# Altium schematic sheet mega module.SchDoc("mega module")
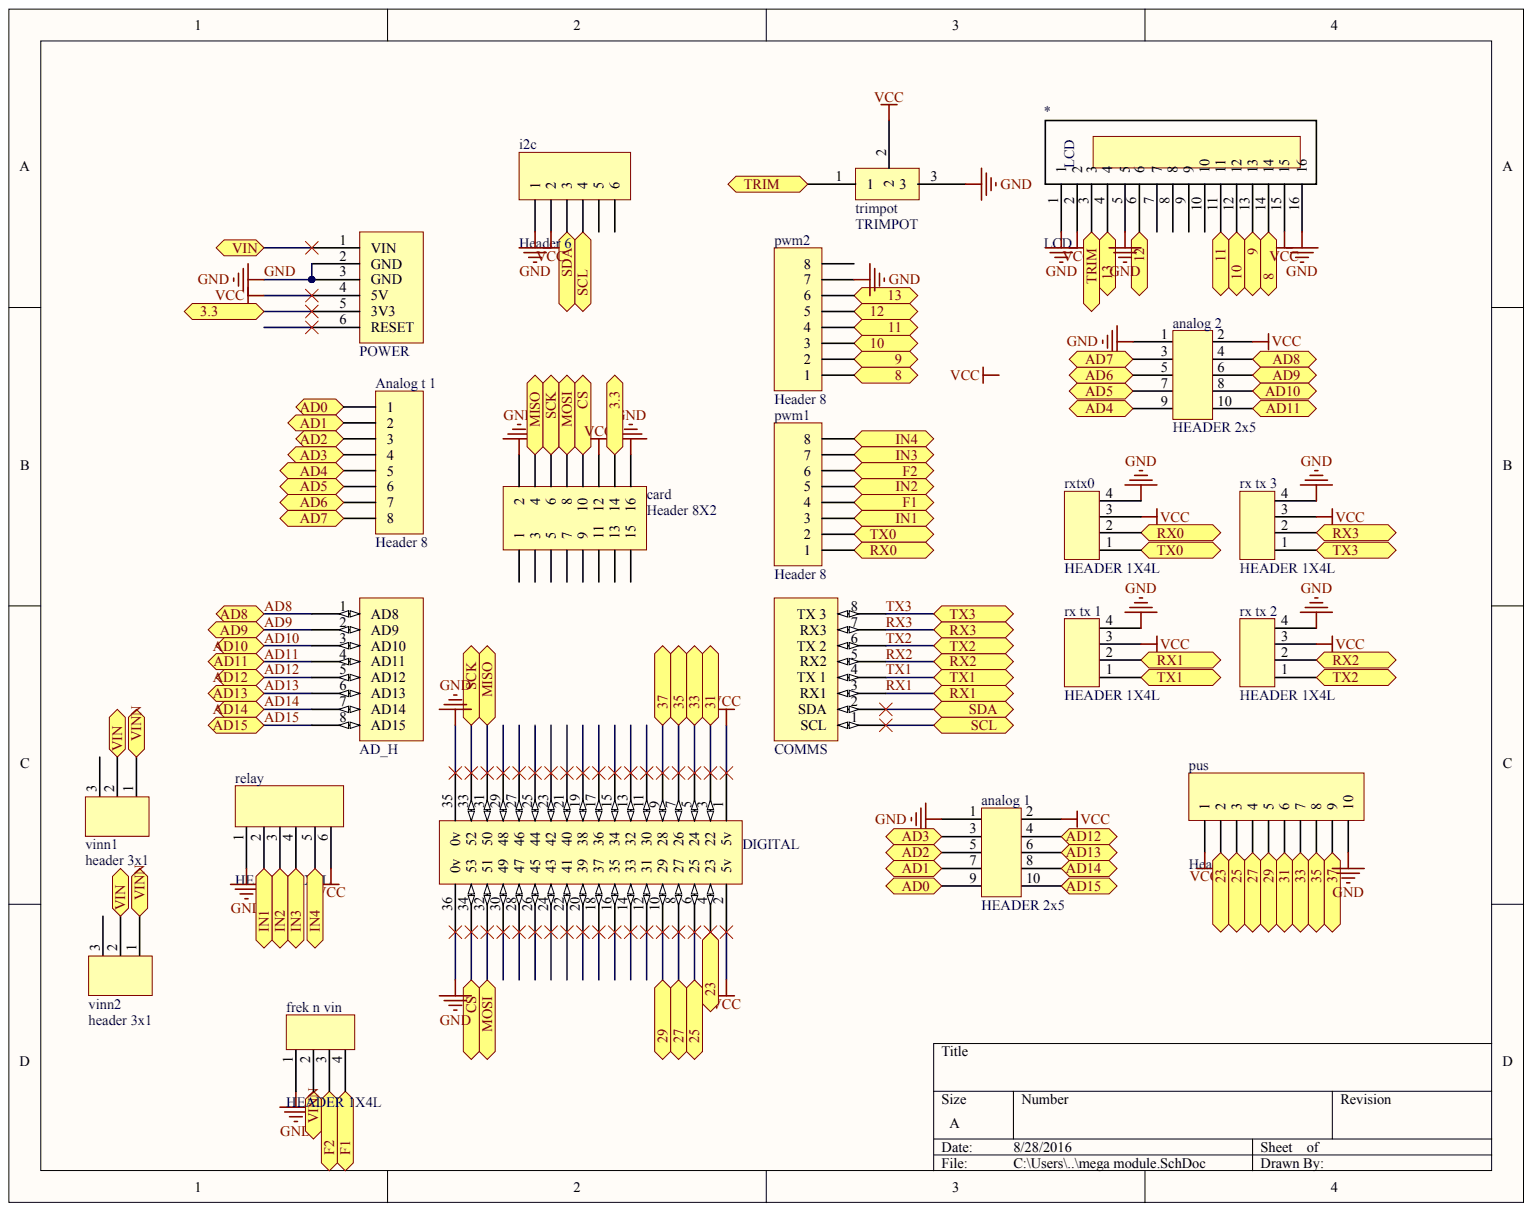
[diagram p0: mega module.SchDoc]

## Parts
*  LCD
AD_H  AD_H
analog_1  HEADER 2x5
analog_2  HEADER 2x5
Analog_t_1  Header 8
card  Header 8X2
COMMS  Communication  (value not drawn)
DIGITAL  IO_36  (value not drawn)
frek_n_vin  HEADER 1X4L
i2c  Header 6
POWER  POWER
pus  Header 10
pwm1  Header 8
pwm2  Header 8
relay  HEADER 1X6L
rx_tx_1  HEADER 1X4L
rx_tx_2  HEADER 1X4L
rx_tx_3  HEADER 1X4L
rxtx0  HEADER 1X4L
trimpot  TRIMPOT
vinn1  header 3x1
vinn2  header 3x1

## Ports
3.3, 8, 9, 10, 11, 12, 13, 23, 25, 27, 29, 31, 33, 35, 37, AD0, AD1, AD2, AD3, AD4, AD5, AD6, AD7, AD8, AD9, AD10, AD11, AD12, AD13, AD14, AD15, CS, F1, F2, IN1, IN2, IN3, IN4, MISO, MOSI, RX0, RX1, RX2, RX3, SCK, SCL, SDA, TRIM, TX0, TX1, TX2, TX3, VIN, VINN

## Nets
VCC: *.2 *.15 analog_1.2 analog_2.2 card.12 DIGITAL.1(5v) DIGITAL.2(5v) i2c.2 POWER.4(5V) pus.1 relay.6 rx_tx_1.3 rx_tx_2.3 rx_tx_3.3 rxtx0.3 trimpot.2
3.3: card.14 POWER.5(3V3) ; port 3.3
8: *.14 pwm2.1 ; port 8
9: *.13 pwm2.2 ; port 9
10: *.12 pwm2.3 ; port 10
11: *.11 pwm2.4 ; port 11
12: *.6 pwm2.5 ; port 12
13: *.4 pwm2.6 ; port 13
23: DIGITAL.4 pus.2 ; port 23
25: DIGITAL.6 pus.3 ; port 25
27: DIGITAL.8 pus.4 ; port 27
29: DIGITAL.10 pus.5 ; port 29
31: DIGITAL.3 pus.6 ; port 31
33: DIGITAL.5 pus.7 ; port 33
35: DIGITAL.7 pus.8 ; port 35
37: DIGITAL.9 pus.9 ; port 37
AD0: analog_1.9 Analog_t_1.1 ; port AD0
AD1: analog_1.7 Analog_t_1.2 ; port AD1
AD2: analog_1.5 Analog_t_1.3 ; port AD2
AD3: analog_1.3 Analog_t_1.4 ; port AD3
AD4: analog_2.9 Analog_t_1.5 ; port AD4
AD5: analog_2.7 Analog_t_1.6 ; port AD5
AD6: analog_2.5 Analog_t_1.7 ; port AD6
AD7: analog_2.3 Analog_t_1.8 ; port AD7
AD8: AD_H.1(AD8) analog_2.4 ; port AD8
AD9: AD_H.2(AD9) analog_2.6 ; port AD9
AD10: AD_H.3(AD10) analog_2.8 ; port AD10
AD11: AD_H.4(AD11) analog_2.10 ; port AD11
AD12: AD_H.5(AD12) analog_1.4 ; port AD12
AD13: AD_H.6(AD13) analog_1.6 ; port AD13
AD14: AD_H.7(AD14) analog_1.8 ; port AD14
AD15: AD_H.8(AD15) analog_1.10 ; port AD15
GND: *.1 *.5 *.16 analog_1.1 analog_2.1 card.2 card.16 DIGITAL.35(0v) DIGITAL.36(0v) frek_n_vin.1 i2c.1 POWER.2(GND) POWER.3(GND) pus.10 pwm2.7 relay.1 rx_tx_1.4 rx_tx_2.4 rx_tx_3.4 rxtx0.4 trimpot.3
IN1: pwm1.3 relay.2 ; port IN1
IN2: pwm1.5 relay.3 ; port IN2
IN3: pwm1.7 relay.4 ; port IN3
IN4: pwm1.8 relay.5 ; port IN4
RX1: COMMS.3(RX1) rx_tx_1.2 ; port RX1
RX2: COMMS.5(RX2) rx_tx_2.2 ; port RX2
RX3: COMMS.7(RX3) rx_tx_3.2 ; port RX3
SCL: COMMS.1(SCL) i2c.4 ; port SCL
SDA: COMMS.2(SDA) i2c.3 ; port SDA
TX1: COMMS.4(TX_1) rx_tx_1.1 ; port TX1
TX2: COMMS.6(TX_2) rx_tx_2.1 ; port TX2
TX3: COMMS.8(TX_3) rx_tx_3.1 ; port TX3
Net*_3: *.3 trimpot.1 ; port TRIM
Net*_7: *.7
Net*_8: *.8
Net*_9: *.9
Net*_10: *.10
Netcard_1: card.1
Netcard_3: card.3
Netcard_4: card.4 DIGITAL.31 ; port MISO
Netcard_5: card.5
Netcard_6: card.6 DIGITAL.33 ; port SCK
Netcard_7: card.7
Netcard_8: card.8 DIGITAL.32 ; port MOSI
Netcard_9: card.9
Netcard_10: card.10 DIGITAL.34 ; port CS
Netcard_11: card.11
Netcard_13: card.13
Netcard_15: card.15
NetDIGITAL_11: DIGITAL.11
NetDIGITAL_12: DIGITAL.12
NetDIGITAL_13: DIGITAL.13
NetDIGITAL_14: DIGITAL.14
NetDIGITAL_15: DIGITAL.15
NetDIGITAL_16: DIGITAL.16
NetDIGITAL_17: DIGITAL.17
NetDIGITAL_18: DIGITAL.18
NetDIGITAL_19: DIGITAL.19
NetDIGITAL_20: DIGITAL.20
NetDIGITAL_21: DIGITAL.21
NetDIGITAL_22: DIGITAL.22
NetDIGITAL_23: DIGITAL.23
NetDIGITAL_24: DIGITAL.24
NetDIGITAL_25: DIGITAL.25
NetDIGITAL_26: DIGITAL.26
NetDIGITAL_27: DIGITAL.27
NetDIGITAL_28: DIGITAL.28
NetDIGITAL_29: DIGITAL.29
NetDIGITAL_30: DIGITAL.30
Netfrek n vin_2: frek_n_vin.2 vinn1.1 vinn2.1 ; port VINN
Netfrek n vin_3: frek_n_vin.3 pwm1.6 ; port F2
Netfrek n vin_4: frek_n_vin.4 pwm1.4 ; port F1
Neti2c_5: i2c.5
Neti2c_6: i2c.6
NetPOWER_1: POWER.1(VIN) vinn1.2 vinn2.2 ; port VIN
NetPOWER_6: POWER.6(RESET)
Netpwm1_1: pwm1.1 rxtx0.2 ; port RX0
Netpwm1_2: pwm1.2 rxtx0.1 ; port TX0
Netpwm2_8: pwm2.8
Netvinn1_3: vinn1.3
Netvinn2_3: vinn2.3

## Library data of the parts
* AD_H analog_1 analog_2 Analog_t_1 card COMMS DIGITAL frek_n_vin i2c POWER pus pwm1 pwm2 relay rx_tx_1-rx_tx_3 rxtx0 trimpot vinn1 vinn2 = (no symbol name)
  Analog_t_1 card i2c pus pwm1 pwm2: LatestRevisionDate: 17-Jul-2002; LatestRevisionNote: Re-released for DXP Platform.; Publisher: Altium Limited
